# Altium schematic sheet P03_Processor 1 of 3, JTAG Header.SchDoc
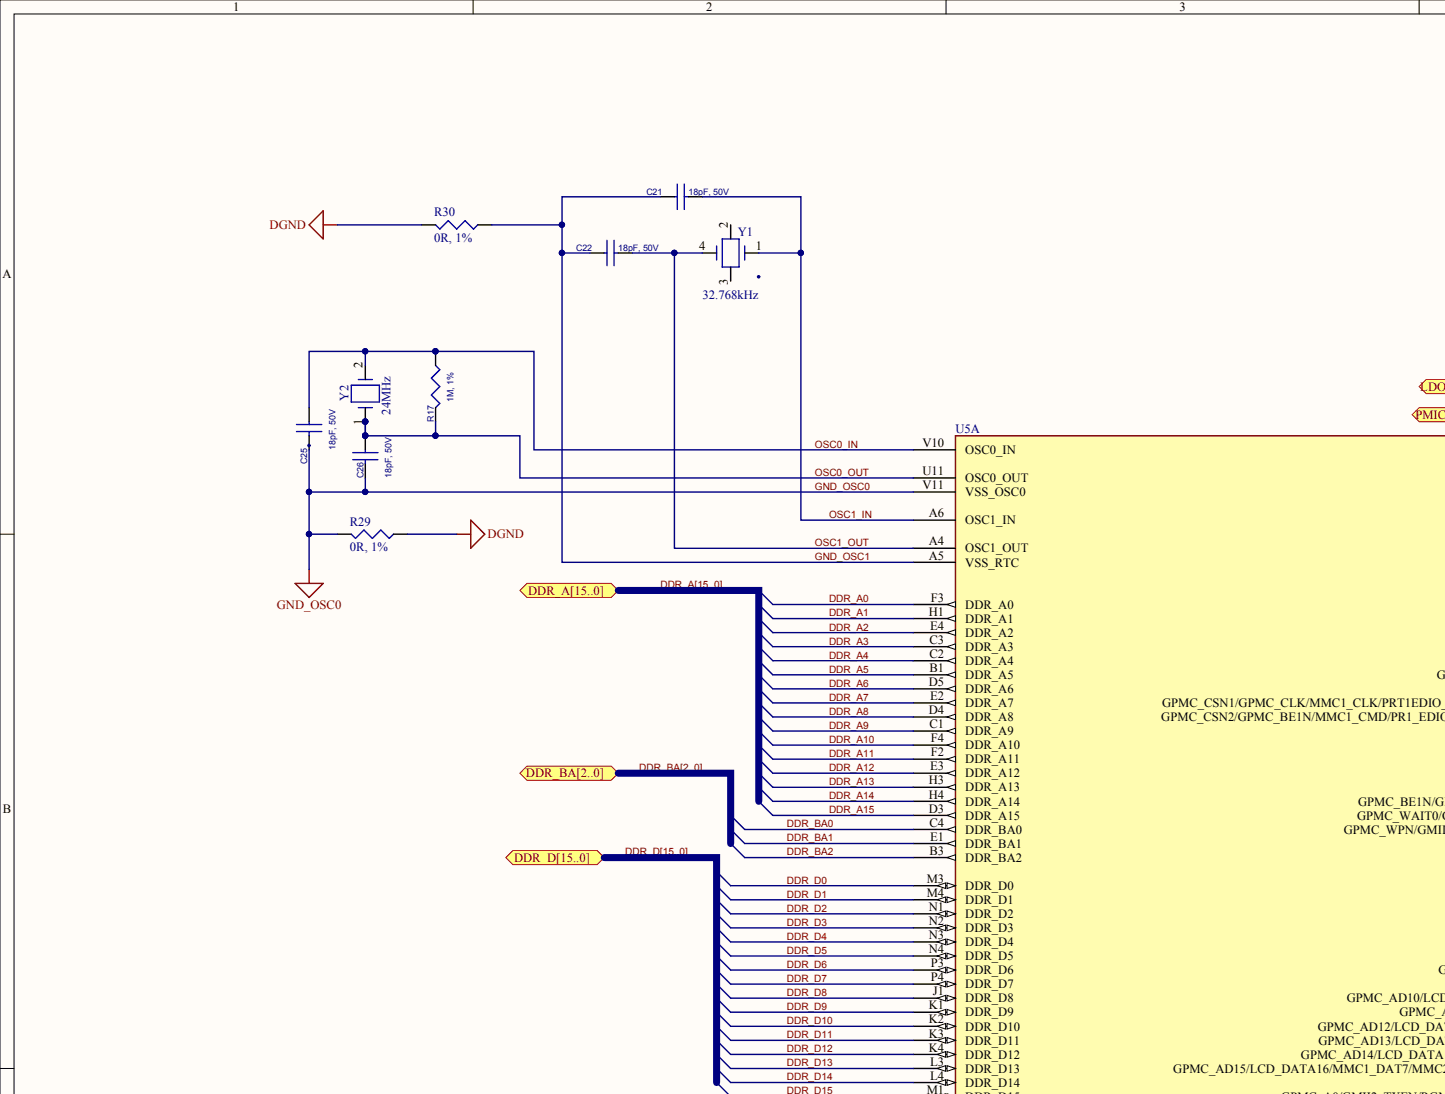
[diagram p0: P03_Processor 1 of 3, JTAG Header.SchDoc, top left]
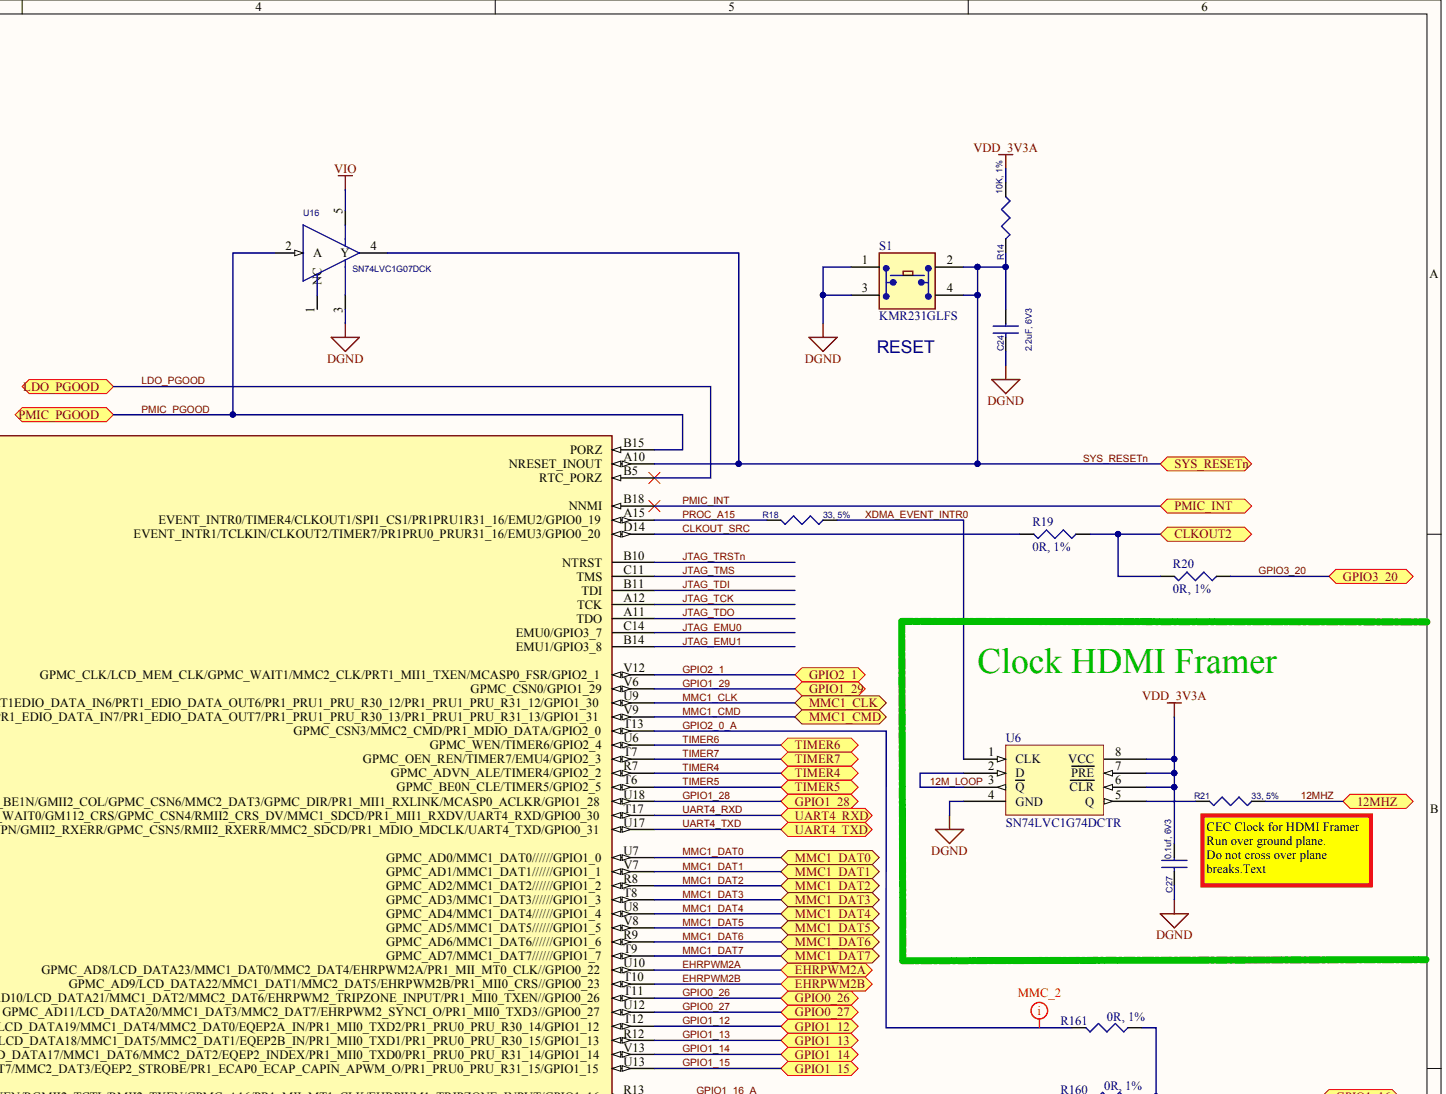
[diagram p1: P03_Processor 1 of 3, JTAG Header.SchDoc, top right]
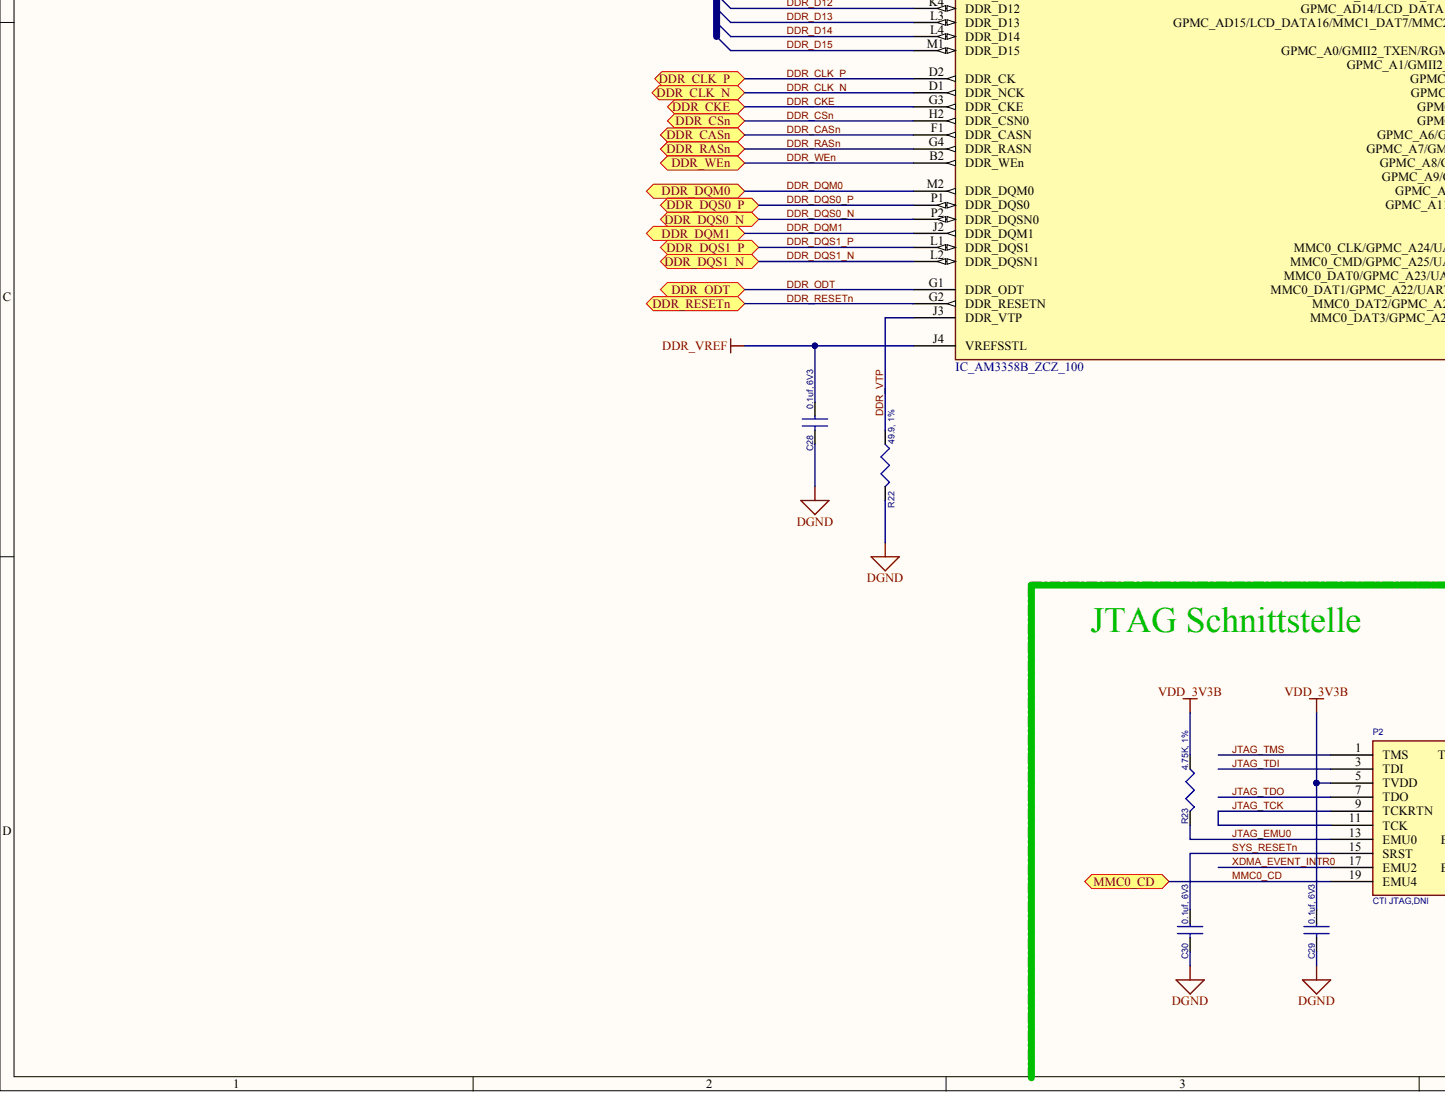
[diagram p2: P03_Processor 1 of 3, JTAG Header.SchDoc, bottom left]
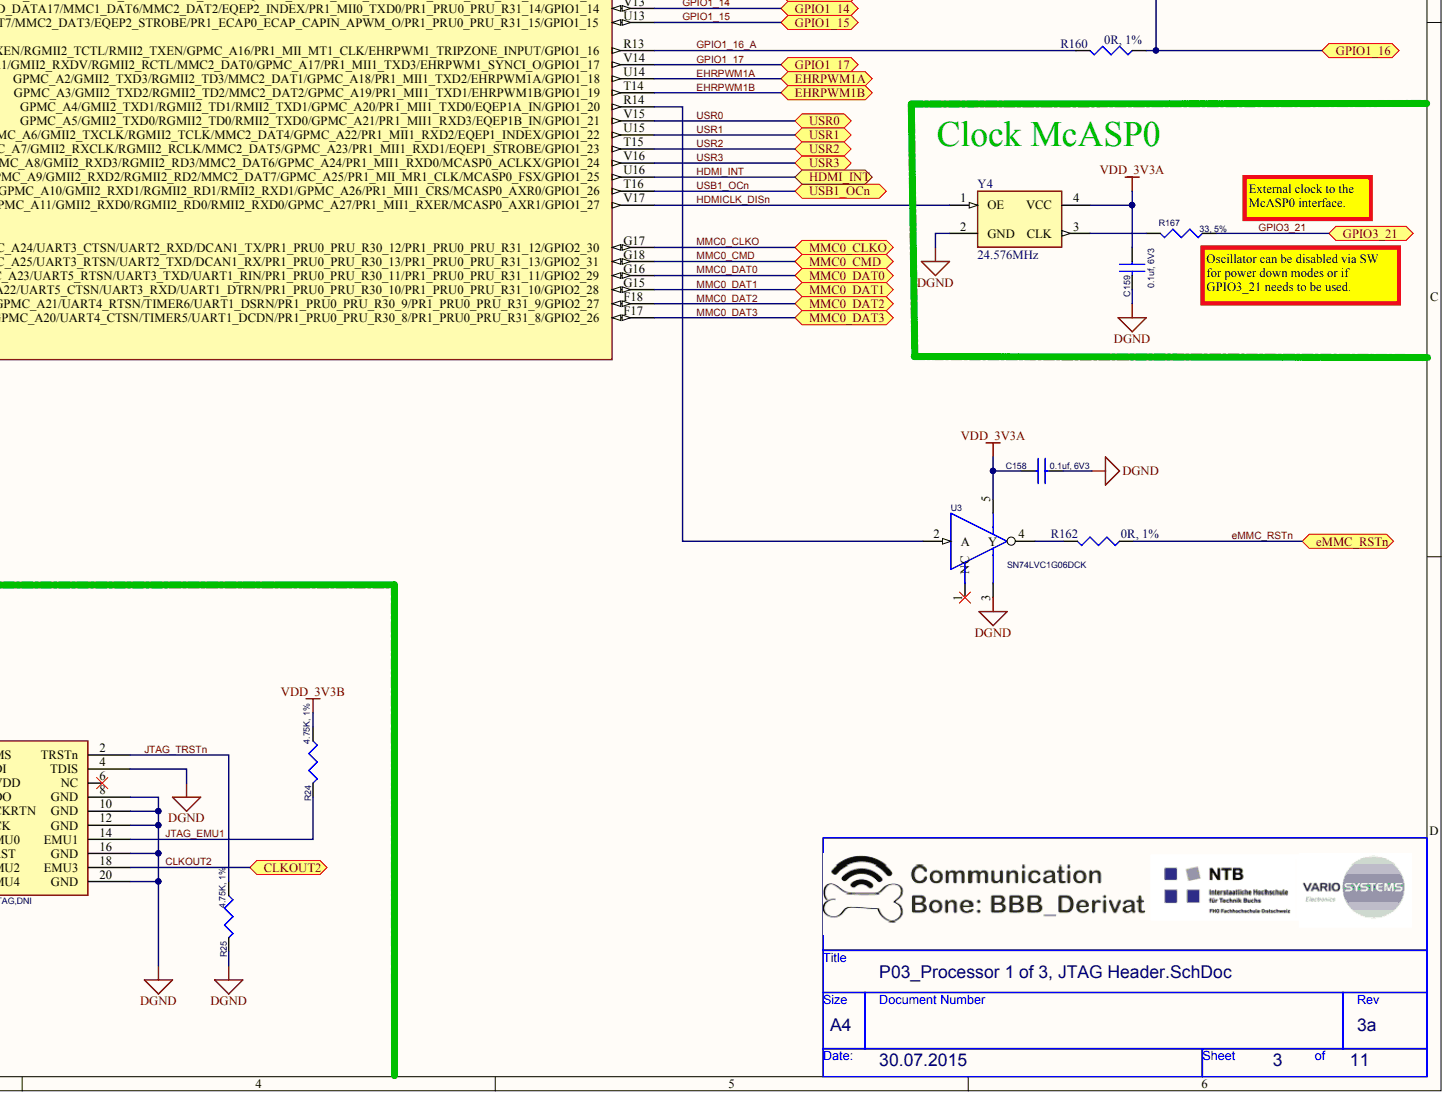
[diagram p3: P03_Processor 1 of 3, JTAG Header.SchDoc, bottom right]

## Parts
C21  18pF, 50V  Voltage - Rated: 50V  [402]
C22  18pF, 50V  Voltage - Rated: 50V  [402]
C24  2.2uF, 6V3  [402]
C25  18pF, 50V  Voltage - Rated: 50V  [402]
C26  18pF, 50V  Voltage - Rated: 50V  [402]
C27  0.1uf, 6V3  [402]
C28  0.1uf, 6V3  [402]
C29  0.1uf, 6V3  [402]
C30  0.1uf, 6V3  [402]
C158  0.1uf, 6V3  [402]
C159  0.1uf, 6V3  [402]
P2  CTI JTAG,DNI  [HEADER10X2_SMD]
R14  10K, 1%  [402]
R17  1M, 1%  [402]
R18  33, 5%  [402]
R19  0R, 1%  [402]
R20  0R, 1%  [402]
R21  33, 5%  [402]
R22  49.9, 1%  [402]
R23  4.75K, 1%  [402]
R24  4.75K, 1%  [402]
R25  4.75K, 1%  [402]
R29  0R, 1%  [402]
R30  0R, 1%  [402]
R160  0R, 1%  [402]
R161  0R, 1%  [402]
R162  0R, 1%  [402]
R167  33, 5%  [402]
S1  KMR231GLFS  [SW4SMD_63X161LS]
U3  SN74LVC1G06DCK  [DCK5_2P15X1P4]
U5  IC_AM3358B_ZCZ_100  [am33xx_15x15]  (part A)
U6  SN74LVC1G74DCTR  [SOP8_DCT]
U16  SN74LVC1G07DCK  [DCK5_2P15X1P4]
Y1  32.768kHz  [XTAL4_126-216SMD]
Y2  24MHz  [XTAL150SMD_125X196]
Y4  24.576MHz  [OSC4_61X65LS_79X98SMD]

## Ports
12MHZ, CLKOUT2, DDR_A0, DDR_A1, DDR_A2, DDR_A3, DDR_A4, DDR_A5, DDR_A6, DDR_A7, DDR_A8, DDR_A9, DDR_A10, DDR_A11, DDR_A12, DDR_A13, DDR_A14, DDR_A15, DDR_A[15..0], DDR_BA0, DDR_BA1, DDR_BA2, DDR_BA[2..0], DDR_CASN, DDR_CKE, DDR_CLK_N, DDR_CLK_P, DDR_CSN, DDR_D0, DDR_D1, DDR_D2, DDR_D3, DDR_D4, DDR_D5, DDR_D6, DDR_D7, DDR_D8, DDR_D9, DDR_D10, DDR_D11, DDR_D12, DDR_D13, DDR_D14, DDR_D15, DDR_D[15..0], DDR_DQM0, DDR_DQM1, DDR_DQS0_N, DDR_DQS0_P, DDR_DQS1_N, DDR_DQS1_P, DDR_ODT, DDR_RASN, DDR_RESETN, DDR_WEN, EHRPWM1A, EHRPWM1B, EHRPWM2A, EHRPWM2B, EMMC_RSTN, GPIO0_26, GPIO0_27, GPIO1_12, GPIO1_13, GPIO1_14, GPIO1_15, GPIO1_16, GPIO1_17, GPIO1_28, GPIO1_29, GPIO2_1, GPIO3_20, GPIO3_21, HDMI_INT, LDO_PGOOD, MMC0_CD, MMC0_CLKO, MMC0_CMD, MMC0_DAT0, MMC0_DAT1, MMC0_DAT2, MMC0_DAT3, MMC1_CLK, MMC1_CMD, MMC1_DAT0, MMC1_DAT1, MMC1_DAT2, MMC1_DAT3, MMC1_DAT4, MMC1_DAT5, MMC1_DAT6, MMC1_DAT7, PMIC_INT, PMIC_PGOOD, SYS_RESETN, TIMER4, TIMER5, TIMER6, TIMER7, UART4_RXD, UART4_TXD, USB1_OCN, USR0, USR1, USR2, USR3

## Nets
DDR_VREF: C28.1 U5.J4(VREFSSTL)
DGND: C24.2 C27.2 C28.2 C29.2 C30.2 C158.2 C159.2 P2.4(TDIS) P2.8(GND) P2.10(GND) P2.12(GND) P2.16(GND) P2.20(GND) R22.1 R25.2 R29.2 R30.1 S1.1 S1.3 U3.3 U6.4(GND) U16.3 Y4.2(GND)
VDD_3V3A: C27.1 C158.1 C159.1 R14.1 U3.5 U6.6(CLR) U6.7(PRE) U6.8(VCC) Y4.4(VCC)
VDD_3V3B: C29.1 P2.5(TVDD) R23.1 R24.1
VIO: U16.5
12M_LOOP: U6.2(D) U6.3(Q)
12MHZ: R21.2 ; port 12MHZ
CLKOUT2: P2.18(EMU3) R19.2 R20.1 ; port CLKOUT2
CLKOUT_SRC: R19.1 U5.D14(EVENT_INTR1/TCLKIN/CLKOUT2/TIMER7/PR1PRU0_PRUR31_16/EMU3/GPIO0_20)
DDR_A0: U5.F3(DDR_A0) ; port DDR_A[15..0]  [also labelled DDR_A]
DDR_A1: U5.H1(DDR_A1) ; port DDR_A[15..0]  [also labelled DDR_A]
DDR_A2: U5.E4(DDR_A2) ; port DDR_A[15..0]  [also labelled DDR_A]
DDR_A3: U5.C3(DDR_A3) ; port DDR_A[15..0]  [also labelled DDR_A]
DDR_A4: U5.C2(DDR_A4) ; port DDR_A[15..0]  [also labelled DDR_A]
DDR_A5: U5.B1(DDR_A5) ; port DDR_A[15..0]  [also labelled DDR_A]
DDR_A6: U5.D5(DDR_A6) ; port DDR_A[15..0]  [also labelled DDR_A]
DDR_A7: U5.E2(DDR_A7) ; port DDR_A[15..0]  [also labelled DDR_A]
DDR_A8: U5.D4(DDR_A8) ; port DDR_A[15..0]  [also labelled DDR_A]
DDR_A9: U5.C1(DDR_A9) ; port DDR_A[15..0]  [also labelled DDR_A]
DDR_A10: U5.F4(DDR_A10) ; port DDR_A[15..0]  [also labelled DDR_A]
DDR_A11: U5.F2(DDR_A11) ; port DDR_A[15..0]  [also labelled DDR_A]
DDR_A12: U5.E3(DDR_A12) ; port DDR_A[15..0]  [also labelled DDR_A]
DDR_A13: U5.H3(DDR_A13) ; port DDR_A[15..0]  [also labelled DDR_A]
DDR_A14: U5.H4(DDR_A14) ; port DDR_A[15..0]  [also labelled DDR_A]
DDR_A15: U5.D3(DDR_A15) ; port DDR_A[15..0]  [also labelled DDR_A]
DDR_BA0: U5.C4(DDR_BA0) ; port DDR_BA[2..0]  [also labelled DDR_BA]
DDR_BA1: U5.E1(DDR_BA1) ; port DDR_BA[2..0]  [also labelled DDR_BA]
DDR_BA2: U5.B3(DDR_BA2) ; port DDR_BA[2..0]  [also labelled DDR_BA]
DDR_CASN: U5.F1(DDR_CASN) ; port DDR_CASN
DDR_CKE: U5.G3(DDR_CKE) ; port DDR_CKE
DDR_CLK_N: U5.D1(DDR_NCK) ; port DDR_CLK_N
DDR_CLK_P: U5.D2(DDR_CK) ; port DDR_CLK_P
DDR_CSN: U5.H2(DDR_CSN0) ; port DDR_CSN
DDR_D0: U5.M3(DDR_D0) ; port DDR_D[15..0]  [also labelled DDR_D]
DDR_D1: U5.M4(DDR_D1) ; port DDR_D[15..0]  [also labelled DDR_D]
DDR_D2: U5.N1(DDR_D2) ; port DDR_D[15..0]  [also labelled DDR_D]
DDR_D3: U5.N2(DDR_D3) ; port DDR_D[15..0]  [also labelled DDR_D]
DDR_D4: U5.N3(DDR_D4) ; port DDR_D[15..0]  [also labelled DDR_D]
DDR_D5: U5.N4(DDR_D5) ; port DDR_D[15..0]  [also labelled DDR_D]
DDR_D6: U5.P3(DDR_D6) ; port DDR_D[15..0]  [also labelled DDR_D]
DDR_D7: U5.P4(DDR_D7) ; port DDR_D[15..0]  [also labelled DDR_D]
DDR_D8: U5.J1(DDR_D8) ; port DDR_D[15..0]  [also labelled DDR_D]
DDR_D9: U5.K1(DDR_D9) ; port DDR_D[15..0]  [also labelled DDR_D]
DDR_D10: U5.K2(DDR_D10) ; port DDR_D[15..0]  [also labelled DDR_D]
DDR_D11: U5.K3(DDR_D11) ; port DDR_D[15..0]  [also labelled DDR_D]
DDR_D12: U5.K4(DDR_D12) ; port DDR_D[15..0]  [also labelled DDR_D]
DDR_D13: U5.L3(DDR_D13) ; port DDR_D[15..0]  [also labelled DDR_D]
DDR_D14: U5.L4(DDR_D14) ; port DDR_D[15..0]  [also labelled DDR_D]
DDR_D15: U5.M1(DDR_D15) ; port DDR_D[15..0]  [also labelled DDR_D]
DDR_DQM0: U5.M2(DDR_DQM0) ; port DDR_DQM0
DDR_DQM1: U5.J2(DDR_DQM1) ; port DDR_DQM1
DDR_DQS0_N: U5.P2(DDR_DQSN0) ; port DDR_DQS0_N
DDR_DQS0_P: U5.P1(DDR_DQS0) ; port DDR_DQS0_P
DDR_DQS1_N: U5.L2(DDR_DQSN1) ; port DDR_DQS1_N
DDR_DQS1_P: U5.L1(DDR_DQS1) ; port DDR_DQS1_P
DDR_ODT: U5.G1(DDR_ODT) ; port DDR_ODT
DDR_RASN: U5.G4(DDR_RASN) ; port DDR_RASN
DDR_RESETN: U5.G2(DDR_RESETN) ; port DDR_RESETN
DDR_VTP: R22.2 U5.J3(DDR_VTP)
DDR_WEN: U5.B2(DDR_WEn) ; port DDR_WEN
EHRPWM1A: U5.U14(GPMC_A2/GMII2_TXD3/RGMII2_TD3/MMC2_DAT1/GPMC_A18/PR1_MII1_TXD2/EHRPWM1A/GPIO1_18) ; port EHRPWM1A
EHRPWM1B: U5.T14(GPMC_A3/GMII2_TXD2/RGMII2_TD2/MMC2_DAT2/GPMC_A19/PR1_MII1_TXD1/EHRPWM1B/GPIO1_19) ; port EHRPWM1B
EHRPWM2A: U5.U10(GPMC_AD8/LCD_DATA23/MMC1_DAT0/MMC2_DAT4/EHRPWM2A/PR1_MII_MT0_CLK//GPIO0_22) ; port EHRPWM2A
EHRPWM2B: U5.T10(GPMC_AD9/LCD_DATA22/MMC1_DAT1/MMC2_DAT5/EHRPWM2B/PR1_MII0_CRS//GPIO0_23) ; port EHRPWM2B
EMMC_RSTN: R162.2 ; port EMMC_RSTN
GND_OSC0: C25.2 C26.2 R29.1 U5.V11(VSS_OSC0)
GND_OSC1: C21.1 C22.1 R30.2 U5.A5(VSS_RTC)
GPIO0_26: U5.T11(GPMC_AD10/LCD_DATA21/MMC1_DAT2/MMC2_DAT6/EHRPWM2_TRIPZONE_INPUT/PR1_MII0_TXEN//GPIO0_26) ; port GPIO0_26
GPIO0_27: U5.U12(GPMC_AD11/LCD_DATA20/MMC1_DAT3/MMC2_DAT7/EHRPWM2_SYNCI_O/PR1_MII0_TXD3//GPIO0_27) ; port GPIO0_27
GPIO1_12: U5.T12(GPMC_AD12/LCD_DATA19/MMC1_DAT4/MMC2_DAT0/EQEP2A_IN/PR1_MII0_TXD2/PR1_PRU0_PRU_R30_14/GPIO1_12) ; port GPIO1_12
GPIO1_13: U5.R12(GPMC_AD13/LCD_DATA18/MMC1_DAT5/MMC2_DAT1/EQEP2B_IN/PR1_MII0_TXD1/PR1_PRU0_PRU_R30_15/GPIO1_13) ; port GPIO1_13
GPIO1_14: U5.V13(GPMC_AD14/LCD_DATA17/MMC1_DAT6/MMC2_DAT2/EQEP2_INDEX/PR1_MII0_TXD0/PR1_PRU0_PRU_R31_14/GPIO1_14) ; port GPIO1_14
GPIO1_15: U5.U13(GPMC_AD15/LCD_DATA16/MMC1_DAT7/MMC2_DAT3/EQEP2_STROBE/PR1_ECAP0_ECAP_CAPIN_APWM_O/PR1_PRU0_PRU_R31_15/GPIO1_15) ; port GPIO1_15
GPIO1_16: R160.2 R161.2 ; port GPIO1_16
GPIO1_16_A: R160.1 U5.R13(GPMC_A0/GMII2_TXEN/RGMII2_TCTL/RMII2_TXEN/GPMC_A16/PR1_MII_MT1_CLK/EHRPWM1_TRIPZONE_INPUT/GPIO1_16)
GPIO1_17: U5.V14(GPMC_A1/GMII2_RXDV/RGMII2_RCTL/MMC2_DAT0/GPMC_A17/PR1_MII1_TXD3/EHRPWM1_SYNCI_O/GPIO1_17) ; port GPIO1_17
GPIO1_28: U5.U18(GPMC_BE1N/GMII2_COL/GPMC_CSN6/MMC2_DAT3/GPMC_DIR/PR1_MII1_RXLINK/MCASP0_ACLKR/GPIO1_28) ; port GPIO1_28
GPIO1_29: U5.V6(GPMC_CSN0/GPIO1_29) ; port GPIO1_29
GPIO2_0_A: R161.1 U5.T13(GPMC_CSN3/MMC2_CMD/PR1_MDIO_DATA/GPIO2_0)
GPIO2_1: U5.V12(GPMC_CLK/LCD_MEM_CLK/GPMC_WAIT1/MMC2_CLK/PRT1_MII1_TXEN/MCASP0_FSR/GPIO2_1) ; port GPIO2_1
GPIO3_20: R20.2 ; port GPIO3_20
GPIO3_21: R167.2 ; port GPIO3_21
HDMI_INT: U5.U16(GPMC_A9/GMII2_RXD2/RGMII2_RD2/MMC2_DAT7/GPMC_A25/PR1_MII_MR1_CLK/MCASP0_FSX/GPIO1_25) ; port HDMI_INT
HDMICLK_DISN: U5.V17(GPMC_A11/GMII2_RXD0/RGMII2_RD0/RMII2_RXD0/GPMC_A27/PR1_MII1_RXER/MCASP0_AXR1/GPIO1_27) Y4.1(OE)
JTAG_EMU0: P2.13(EMU0) R23.2 U5.C14(EMU0/GPIO3_7)
JTAG_EMU1: P2.14(EMU1) R24.2 U5.B14(EMU1/GPIO3_8)
JTAG_TCK: P2.9(TCKRTN) P2.11(TCK) U5.A12(TCK)
JTAG_TDI: P2.3(TDI) U5.B11(TDI)
JTAG_TDO: P2.7(TDO) U5.A11(TDO)
JTAG_TMS: P2.1(TMS) U5.C11(TMS)
JTAG_TRSTN: P2.2(TRSTn) R25.1 U5.B10(NTRST)
LDO_PGOOD: U5.B5(RTC_PORZ) ; port LDO_PGOOD
MMC0_CD: P2.19(EMU4) ; port MMC0_CD
MMC0_CLKO: U5.G17(MMC0_CLK/GPMC_A24/UART3_CTSN/UART2_RXD/DCAN1_TX/PR1_PRU0_PRU_R30_12/PR1_PRU0_PRU_R31_12/GPIO2_30) ; port MMC0_CLKO
MMC0_CMD: U5.G18(MMC0_CMD/GPMC_A25/UART3_RTSN/UART2_TXD/DCAN1_RX/PR1_PRU0_PRU_R30_13/PR1_PRU0_PRU_R31_13/GPIO2_31) ; port MMC0_CMD
MMC0_DAT0: U5.G16(MMC0_DAT0/GPMC_A23/UART5_RTSN/UART3_TXD/UART1_RIN/PR1_PRU0_PRU_R30_11/PR1_PRU0_PRU_R31_11/GPIO2_29) ; port MMC0_DAT0
MMC0_DAT1: U5.G15(MMC0_DAT1/GPMC_A22/UART5_CTSN/UART3_RXD/UART1_DTRN/PR1_PRU0_PRU_R30_10/PR1_PRU0_PRU_R31_10/GPIO2_28) ; port MMC0_DAT1
MMC0_DAT2: U5.F18(MMC0_DAT2/GPMC_A21/UART4_RTSN/TIMER6/UART1_DSRN/PR1_PRU0_PRU_R30_9/PR1_PRU0_PRU_R31_9/GPIO2_27) ; port MMC0_DAT2
MMC0_DAT3: U5.F17(MMC0_DAT3/GPMC_A20/UART4_CTSN/TIMER5/UART1_DCDN/PR1_PRU0_PRU_R30_8/PR1_PRU0_PRU_R31_8/GPIO2_26) ; port MMC0_DAT3
MMC1_CLK: U5.U9(GPMC_CSN1/GPMC_CLK/MMC1_CLK/PRT1EDIO_DATA_IN6/PRT1_EDIO_DATA_OUT6/PR1_PRU1_PRU_R30_12/PR1_PRU1_PRU_R31_12/GPIO1_30) ; port MMC1_CLK
MMC1_CMD: U5.V9(GPMC_CSN2/GPMC_BE1N/MMC1_CMD/PR1_EDIO_DATA_IN7/PR1_EDIO_DATA_OUT7/PR1_PRU1_PRU_R30_13/PR1_PRU1_PRU_R31_13/GPIO1_31) ; port MMC1_CMD
MMC1_DAT0: U5.U7(GPMC_AD0/MMC1_DAT0//////GPIO1_0) ; port MMC1_DAT0
MMC1_DAT1: U5.V7(GPMC_AD1/MMC1_DAT1//////GPIO1_1) ; port MMC1_DAT1
MMC1_DAT2: U5.R8(GPMC_AD2/MMC1_DAT2//////GPIO1_2) ; port MMC1_DAT2
MMC1_DAT3: U5.T8(GPMC_AD3/MMC1_DAT3//////GPIO1_3) ; port MMC1_DAT3
MMC1_DAT4: U5.U8(GPMC_AD4/MMC1_DAT4//////GPIO1_4) ; port MMC1_DAT4
MMC1_DAT5: U5.V8(GPMC_AD5/MMC1_DAT5//////GPIO1_5) ; port MMC1_DAT5
MMC1_DAT6: U5.R9(GPMC_AD6/MMC1_DAT6//////GPIO1_6) ; port MMC1_DAT6
MMC1_DAT7: U5.T9(GPMC_AD7/MMC1_DAT7//////GPIO1_7) ; port MMC1_DAT7
OSC0_IN: C25.1 R17.1 U5.V10(OSC0_IN) Y2.2
OSC0_OUT: C26.1 R17.2 U5.U11(OSC0_OUT) Y2.1
OSC1_IN: C21.2 U5.A6(OSC1_IN) Y1.1
OSC1_OUT: C22.2 U5.A4(OSC1_OUT) Y1.4
PMIC_INT: U5.B18(NNMI) ; port PMIC_INT
PMIC_PGOOD: U5.B15(PORZ) U16.2(A) ; port PMIC_PGOOD
PROC_A15: R18.1 U5.A15(EVENT_INTR0/TIMER4/CLKOUT1/SPI1_CS1/PR1PRU1R31_16/EMU2/GPIO0_19)
SYS_RESETN: C24.1 C30.1 P2.15(SRST) R14.2 S1.2 S1.4 U5.A10(NRESET_INOUT) U16.4(Y) ; port SYS_RESETN
TIMER4: U5.R7(GPMC_ADVN_ALE/TIMER4/GPIO2_2) ; port TIMER4
TIMER5: U5.T6(GPMC_BE0N_CLE/TIMER5/GPIO2_5) ; port TIMER5
TIMER6: U5.U6(GPMC_WEN/TIMER6/GPIO2_4) ; port TIMER6
TIMER7: U5.T7(GPMC_OEN_REN/TIMER7/EMU4/GPIO2_3) ; port TIMER7
UART4_RXD: U5.T17(GPMC_WAIT0/GM112_CRS/GPMC_CSN4/RMII2_CRS_DV/MMC1_SDCD/PR1_MII1_RXDV/UART4_RXD/GPIO0_30) ; port UART4_RXD
UART4_TXD: U5.U17(GPMC_WPN/GMII2_RXERR/GPMC_CSN5/RMII2_RXERR/MMC2_SDCD/PR1_MDIO_MDCLK/UART4_TXD/GPIO0_31) ; port UART4_TXD
USB1_OCN: U5.T16(GPMC_A10/GMII2_RXD1/RGMII2_RD1/RMII2_RXD1/GPMC_A26/PR1_MII1_CRS/MCASP0_AXR0/GPIO1_26) ; port USB1_OCN
USR0: U5.V15(GPMC_A5/GMII2_TXD0/RGMII2_TD0/RMII2_TXD0/GPMC_A21/PR1_MII1_RXD3/EQEP1B_IN/GPIO1_21) ; port USR0
USR1: U5.U15(GPMC_A6/GMII2_TXCLK/RGMII2_TCLK/MMC2_DAT4/GPMC_A22/PR1_MII1_RXD2/EQEP1_INDEX/GPIO1_22) ; port USR1
USR2: U5.T15(GPMC_A7/GMII2_RXCLK/RGMII2_RCLK/MMC2_DAT5/GPMC_A23/PR1_MII1_RXD1/EQEP1_STROBE/GPIO1_23) ; port USR2
USR3: U5.V16(GPMC_A8/GMII2_RXD3/RGMII2_RD3/MMC2_DAT6/GPMC_A24/PR1_MII1_RXD0/MCASP0_ACLKX/GPIO1_24) ; port USR3
XDMA_EVENT_INTR0: P2.17(EMU2) R18.2 U6.1(CLK)
NetR21_1: R21.1 U6.5(Q)
NetR162_1: R162.1 U3.4(Y)
NetR167_1: R167.1 Y4.3(CLK)
NetU3_2: U3.2(A) U5.R14(GPMC_A4/GMII2_TXD1/RGMII2_TD1/RMII2_TXD1/GPMC_A20/PR1_MII1_TXD0/EQEP1A_IN/GPIO1_20)

Not connected: P2 6(NC); U3 1(NC); U16 1(NC); Y1 2 3

## Library data of the parts
C21 C22 C25 C26 = CAP_18PF_COG_50V_5%_0402: CAP CER 18PF 50V 5% NP0 0402; Manufacturer: TDK Corporation; Manufacturer Part Number: C1005C0G1H180J050BA; VS Part Number: 256035; Temperature Coefficient: C0G, NP0; Applications: General Purpose; Capacitance: 18pF; Category: Capacitors; Component Kind: Standard; ComponentLink1Description: http://product.tdk.com/capacitor/mlcc/en/documents/mlcc_commercial_general_en.pdf; ComponentLink1URL: http://product.tdk.com/capacitor/mlcc/en/documents/mlcc_commercial_general_en.pdf; Features: -; Height - Seated (Max): -; Lead Spacing: -; Lead Style: -; Mounting Type: Surface Mount, MLCC; Operating Temperature: -55°C ~ 125°C; Package / Case: 0402 (1005 Metric); Packaging: Digi-Reel®; Pricing 1: 1=0.1, 10=0.024, 100=0.011, 250=0.009, 500=0.0077, 1000=0.006, 5000=0.005 (USD); Ratings: -; RoHS: RoHS Compliant; Size / Dimension: 0.039" L x 0.020" W (1.00mm x 0.50mm); Stock 1: 352554; Supplier 1: Digi-Key; Supplier Part Number 1: 445-1238-6-ND; Thickness (Max): 0.022" (0.55mm); Tolerance: ±5%; Library Name: BBB_Derivat.SchLib
C24 = CAP_2.2uF_X5R_6.3V_10%_0402: CAP CER 2.2UF 6.3V 10% X5R 0402; Manufacturer: TDK Corporation; Manufacturer Part Number: C1005X5R0J225K050BC; Capacitance: 2.2µF; Applications: General Purpose; Category: Capacitors; Component Kind: Standard; ComponentLink1Description: http://product.tdk.com/capacitor/mlcc/en/documents/mlcc_commercial_general_en.pdf; ComponentLink1URL: http://product.tdk.com/capacitor/mlcc/en/documents/mlcc_commercial_general_en.pdf; Features: -; Height - Seated (Max): -; Lead Spacing: -; Lead Style: -; Mounting Type: Surface Mount, MLCC; Operating Temperature: -55°C ~ 85°C; Package / Case: 0402 (1005 Metric); Packaging: Digi-Reel®; Pricing 1: 1=0.24, 10=0.2, 100=0.1, 250=0.075, 500=0.06626, 1000=0.05875, 5000=0.04875 (USD); Ratings: -; RoHS: RoHS Compliant; Size / Dimension: 0.039" L x 0.020" W (1.00mm x 0.50mm); Stock 1: 47221; Supplier 1: Digi-Key; Supplier Part Number 1: 445-6847-6-ND; Temperature Coefficient: X5R; Thickness (Max): 0.022" (0.55mm); Tolerance: ±10%; Voltage - Rated: 6.3V; Library Name: BBB_Derivat.SchLib
C27-C30 C158 C159 = CAP_0.1uF_X7R_16V_10% 0402: CAP CER 0.1UF 6.3V 10% X5R 0402; Manufacturer: TDK Corporation; Manufacturer Part Number: C1005X5R0J104K050BA; VS Part Number: 225065; Capacitance: 0.1µF; Applications: General Purpose; Category: Capacitors; Component Kind: Standard; ComponentLink1Description: http://www.kemet.com/datasheets&C0402C104K4RACTU; ComponentLink1URL: http://www.kemet.com/datasheets&C0402C104K4RACTU; Features: -; Height - Seated (Max): -; Lead Spacing: -; Lead Style: -; Mounting Type: Surface Mount, MLCC; Operating Temperature: -55°C ~ 85°C; Package / Case: 0402 (1005 Metric); Packaging: Digi-Reel®; Pricing 1: 1=0.1, 10=0.026, 100=0.0121, 250=0.00992, 500=0.00848, 1000=0.0066, 5000=0.0055 (USD); Ratings: -; RoHS: RoHS Compliant; Size / Dimension: 0.039" L x 0.020" W (1.00mm x 0.50mm); Stock 1: 127604; Supplier 1: Digi-Key; Supplier Part Number 1: 445-1266-6-ND; Temperature Coefficient: X5R; Thickness (Max): 0.022" (0.55mm); Tolerance: ±10%; Voltage - Rated: 6.3V; Library Name: BBB_Derivat.SchLib
P2 = HDR_10x2_CJTAG: Dual Line Header 10x2pin Tin; Manufacturer: Samtec Inc; Manufacturer Part Number: FTR-110-03-G-D-06; Connector Type: Unshrouded; Molding Height Above Board: 0.100" (2.54mm); Number of Positions: 20; Number of Positions Loaded: 19; Pitch: 0.050" (1.27mm); Category: Connectors, Interconnects; Color: Black; Component Kind: Standard; ComponentLink1Description: http://www.samtec.com/documents/webfiles/cpdf/FTR-1XX-XX-XX-D-X-XX-MKT.pdf; ComponentLink1URL: http://www.samtec.com/documents/webfiles/cpdf/FTR-1XX-XX-XX-D-X-XX-MKT.pdf; Contact Finish: Gold; Contact Finish Thickness: 10µin (0.25µm); Contact Mating Length: 0.125" (3.18mm); Features: -; Height Stacking (Mating): -; Mounting Type: Surface Mount; Number of Rows: 2; Packaging: Tube; Pricing 1: 1=4.47 (USD); RoHS: RoHS Compliant; Row Spacing: 0.100" (2.54mm); Stock 1: 0; Supplier 1: Digi-Key; Supplier Part Number 1: FTR-110-03-G-D-06-ND; Termination: Solder; Library Name: BBB_Derivat.SchLib
R14 = RES_10K_1/16W_1%_0402: RES 10K OHM 1/16W 5% 0402 SMD; Manufacturer: Rohm Semiconductor; Manufacturer Part Number: MCR01MZPJ103; VS Part Number: 226218; Power (Watts): 0.063W, 1/16W; Temperature Coefficient: ±200ppm/°C; Category: Resistors; Component Kind: Standard; ComponentLink1Description: http://rohmfs.rohm.com/en/products/databook/datasheet/passive/resistor/chip_resistor/mcr_a.pdf; ComponentLink1URL: http://rohmfs.rohm.com/en/products/databook/datasheet/passive/resistor/chip_resistor/mcr_a.pdf; Composition: Thick Film; Features: Automotive AEC-Q200 Qualified; Height: 0.016" (0.40mm); Number of Terminations: 2; Package / Case: 0402 (1005 Metric); Packaging: Digi-Reel®; Resistance (Ohms): 10k; RoHS: RoHS Compliant; Size / Dimension: 0.039" L x 0.020" W (1.00mm x 0.50mm); Supplier 1: Digi-Key; Supplier Device Package: 0402 (1005 Metric); Supplier Part Number 1: RHM10KCEDKR-ND; Tolerance: ±5%; Library Name: BBB_Derivat.SchLib
R17 = RES_1M_1/16W_1%_0402: Resistor 1Mohm 1/16W 1% 0402; Manufacturer: Stackpole Electronics Inc; Manufacturer Part Number: RMCF0402FT1M00; VS Part Number: 238344; Power (Watts): 0.063W, 1/16W; Temperature Coefficient: ±100ppm/°C; Category: Resistors; Component Kind: Standard; ComponentLink1Description: http://www.seielect.com/Catalog/SEI-RMCF_RMCP.pdf; ComponentLink1URL: http://www.seielect.com/Catalog/SEI-RMCF_RMCP.pdf; Composition: Thick Film; Features: -; Height: 0.016" (0.40mm); Number of Terminations: 2; Package / Case: 0402 (1005 Metric); Packaging: Digi-Reel®; Pricing 1: 1=0.04, 10=0.025, 50=0.0138, 100=0.0105, 250=0.0078, 500=0.00576, 1000=0.00384, 2500=0.00324, 5000=0.0027 (USD); Resistance (Ohms): 1M; RoHS: RoHS Compliant; Size / Dimension: 0.039" L x 0.020" W (1.00mm x 0.50mm); Stock 1: 175650; Supplier 1: Digi-Key; Supplier Device Package: 0402; Supplier Part Number 1: RMCF0402FT1M00DKR-ND; Tolerance: ±1%; Library Name: BBB_Derivat.SchLib
R18 R21 R167 = RES_33_1/16W_5%_0402: RES 33 OHM 1/16W 5% 0402 SMD; Manufacturer: Panasonic Electronic Components; Manufacturer Part Number: ERJ-2GEJ330X; VS Part Number: 254131; Power (Watts): 0.1W, 1/10W; Resistance (Ohms): 33; Temperature Coefficient: ±200ppm/°C; Category: Resistors; Component Kind: Standard; ComponentLink1Description: http://industrial.panasonic.com/www-cgi/jvcr13pz.cgi?E+PZ+3+AOA0001+ERJ2GEJ330X+7+WW; ComponentLink1URL: http://industrial.panasonic.com/www-cgi/jvcr13pz.cgi?E+PZ+3+AOA0001+ERJ2GEJ330X+7+WW; Composition: Thick Film; Features: -; Height: 0.016" (0.40mm); Number of Terminations: 2; Package / Case: 0402 (1005 Metric); Packaging: Digi-Reel®; Pricing 1: 1=0.1, 50=0.0172, 100=0.0131, 250=0.00976, 500=0.0072, 1000=0.0048, 5000=0.00338 (USD); RoHS: RoHS Compliant; Size / Dimension: 0.039" L x 0.020" W (1.00mm x 0.50mm); Stock 1: 5879945; Supplier 1: Digi-Key; Supplier Device Package: 0402; Supplier Part Number 1: P33JDKR-ND; Tolerance: ±5%; Library Name: BBB_Derivat.SchLib
R19 R20 R29 R30 R160-R162 = RES_0_1/16W_1%_0402: RES 0.0 OHM 1/16W 0402 SMD; Manufacturer: Yageo; Manufacturer Part Number: RC0402JR-070RL; VS Part Number: 226229; Power (Watts): 0.063W, 1/16W; Resistance (Ohms): 0.0; Tolerance: Jumper; Category: Resistors; Component Kind: Standard; ComponentLink1Description: http://www.yageo.com/documents/recent/PYu-RC0402_51_RoHS_L_6.pdf; ComponentLink1URL: http://www.yageo.com/documents/recent/PYu-RC0402_51_RoHS_L_6.pdf; Composition: Thick Film; Features: -; Height: 0.016" (0.40mm); Number of Terminations: 2; Package / Case: 0402 (1005 Metric); Packaging: Digi-Reel®; Pricing 1: 1=0.1, 10=0.012, 25=0.0092, 100=0.0055, 250=0.00476, 500=0.00336, 1000=0.00237, 2500=0.00206, 5000=0.00178 (USD); RoHS: RoHS Compliant; Size / Dimension: 0.039" L x 0.020" W (1.00mm x 0.50mm); Stock 1: 4904429; Supplier 1: Digi-Key; Supplier Device Package: 0402; Supplier Part Number 1: 311-0.0JRDKR-ND; Temperature Coefficient: -; Library Name: BBB_Derivat.SchLib
R22 = RES_49.9_1/16_1%_0402: RES 49.9 OHM 1/16W 1% 0402 SMD; Manufacturer: Yageo; Manufacturer Part Number: RC0402FR-0749R9L; Power (Watts): 0.063W, 1/16W; Resistance (Ohms): 49.9; Temperature Coefficient: ±100ppm/°C; Category: Resistors; Component Kind: Standard; ComponentLink1Description: http://www.yageo.com/documents/recent/PYu-RC0402_51_RoHS_L_6.pdf; ComponentLink1URL: http://www.yageo.com/documents/recent/PYu-RC0402_51_RoHS_L_6.pdf; Composition: Thick Film; Features: -; Height: 0.016" (0.40mm); Number of Terminations: 2; Package / Case: 0402 (1005 Metric); Packaging: Digi-Reel®; Pricing 1: 1=0.1, 10=0.014, 25=0.0104, 100=0.0063, 250=0.0054, 500=0.00382, 1000=0.0027, 2500=0.00234, 5000=0.00203 (USD); RoHS: RoHS Compliant; Size / Dimension: 0.039" L x 0.020" W (1.00mm x 0.50mm); Stock 1: 1338786; Supplier 1: Digi-Key; Supplier Device Package: 0402; Supplier Part Number 1: 311-49.9LRDKR-ND; Tolerance: ±1%; Library Name: BBB_Derivat.SchLib
R23-R25 = RES_4.7K_1/16W_1%_0402: RES 4.75K 1/16W 1% 0402 SMD; Manufacturer: Stackpole Electronics Inc; Manufacturer Part Number: RMCF0402FT4K75; Power (Watts): 0.063W, 1/16W; Temperature Coefficient: ±100ppm/°C; Category: Resistors; Component Kind: Standard; ComponentLink1Description: http://www.seielect.com/Catalog/SEI-RMCF_RMCP.pdf; ComponentLink1URL: http://www.seielect.com/Catalog/SEI-RMCF_RMCP.pdf; Composition: Thick Film; Features: -; Height: 0.016" (0.40mm); Number of Terminations: 2; Package / Case: 0402 (1005 Metric); Packaging: Digi-Reel®; Pricing 1: 1=0.04, 10=0.025, 50=0.0138, 100=0.0105, 250=0.0078, 500=0.00576, 1000=0.00384, 2500=0.00324, 5000=0.0027 (USD); Resistance (Ohms): 4.75k; RoHS: RoHS Compliant; Size / Dimension: 0.039" L x 0.020" W (1.00mm x 0.50mm); Stock 1: 280611; Supplier 1: Digi-Key; Supplier Device Package: 0402; Supplier Part Number 1: RMCF0402FT4K75DKR-ND; Tolerance: ±1%; Library Name: BBB_Derivat.SchLib
S1 = KMR2: Microminiature Tact Switch for SMT; Manufacturer: C&K Components; Actuator Height off PCB, from Pins: 1.90mm; Actuator Orientation: Top Actuated; Actuator Type: Standard; Circuit: SPST-NO; Contact Rating @ Voltage: 0.05A @ 32VDC; Illumination: Non-Illuminated; Mechanical Life: 150,000 Cycles; Operating Force: 300gf; Outline: 4.60mm x 2.80mm; Switch Function: Off-Mom; Switch Travel: 0.25mm; Actuator Material: -; Category: Switches; Component Kind: Standard; ComponentLink1Description: http://www.ck-components.com/index.php?module=media&action=Display&cmpref=14414&lang=en&width=&height=&format=&alt=; ComponentLink1URL: http://www.ck-components.com/index.php?module=media&action=Display&cmpref=14414&lang=en&width=&height=&format=&alt=; Features: Grounding Pin; Illumination Type, Color: -; Illumination Voltage (Nominal): -; Ingress Protection: -; Manufacturer Part Number: KMR231GLFS; Mounting Type: Surface Mount; Operating Temperature: -40°C ~ 85°C; Packaging: Digi-Reel®; Pricing 1: 1=0.44, 10=0.416, 25=0.3744, 50=0.3326, 100=0.3188, 250=0.29108, 500=0.2772, 1000=0.22869 (USD); RoHS: RoHS Compliant; Stock 1: 32289; Supplier 1: Digi-Key; Supplier Part Number 1: 401-1429-6-ND; Termination Style: Gull Wing; Library Name: BBB_Derivat.SchLib
U3 = SN74LVC1G06DCK: IC INVERTER SINGLE 1INPUT SC705; Manufacturer: Texas Instruments; Manufacturer Part Number: SN74LVC1G06DCKTG4; Current - Output High, Low: -, 32mA; Current - Quiescent (Max): 10µA; Logic Level - High: 1.7 V ~ 2 V; Logic Level - Low: 0.7 V ~ 0.8 V; Logic Type: Inverter, Buffer; Max Propagation Delay @ V, Max CL: 3ns @ 5V, 50pF; Number of Circuits: 1; Voltage - Supply: 1.65 V ~ 5.5 V; Category: Integrated Circuits (ICs); Component Kind: Standard; ComponentLink1Description: http://www.ti.com/lit/ds/symlink/sn74lvc1g06.pdf; ComponentLink1URL: http://www.ti.com/lit/ds/symlink/sn74lvc1g06.pdf; Features: Open Drain; Mounting Type: Surface Mount; Number of Inputs: 1; Operating Temperature: -40°C ~ 85°C; Package / Case: 6-TSSOP (5 Lead), SC-88A, SOT-353; Packaging: Tape & Reel (TR); RoHS: RoHS Compliant; Supplier 1: Digi-Key; Supplier Device Package: SC-70-5; Supplier Part Number 1: 296-8484-6-ND; Library Name: BBB_Derivat.SchLib
U5 = IC_AM3358B_ZCZ_100: SubArctic Cortex A8 Processor 15x15 1000MHz; Manufacturer: Texas Instruments; Manufacturer Part Number: AM3358ZCZ100; Processor Type: ARM Microprocessor; Voltage: 1.06 V ~ 1.15 V; Category: Integrated Circuits (ICs); Component Kind: Standard; ComponentLink1Description: http://www.ti.com/lit/ds/symlink/am3354.pdf; ComponentLink1URL: http://www.ti.com/lit/ds/symlink/am3354.pdf; Features: -; Mounting Type: Surface Mount; Package / Case: 324-LFBGA; Packaging: Tray; RoHS: RoHS Compliant; Speed: -; Supplier 1: Digi-Key; Supplier Device Package: 324-NFBGA(15x15); Supplier Part Number 1: 296-35869-5-ND; Library Name: BBB_Derivat.SchLib
U6 = SN74LVC1G74DCTR: SINGLE  POSITIVE-EDGE-TRIGGERED  D-TYPE  FLIP-FLOP WITH  CLEAR  AND  PRESET; Manufacturer: Texas Instruments; Current - Output High, Low: 32mA, 32mA; Delay Time - Propagation: 1.6ns; Frequency - Clock: 200MHz; Function: Set(Preset) and Reset; Number of Bits per Element: 1; Output Type: Differential; Trigger Type: Positive Edge; Voltage - Supply: 1.65 V ~ 5.5 V; Category: Integrated Circuits (ICs); Component Kind: Standard; ComponentLink1Description: http://media.digikey.com/pdf/Data%20Sheets/Texas%20Instruments%20PDFs/SN74LVC1G74.pdf; ComponentLink1URL: http://media.digikey.com/pdf/Data%20Sheets/Texas%20Instruments%20PDFs/SN74LVC1G74.pdf; Manufacturer Part Number: SN74LVC1G74DCTR; Mounting Type: Surface Mount; Number of Elements: 1; Operating Temperature: -40°C ~ 125°C; Package / Case: 8-LSSOP (0.11", 2.80mm Width); Packaging: Digi-Reel®; Pricing 1: 1=0.59, 10=0.494, 25=0.4332, 100=0.3708, 250=0.3216, 500=0.2724, 1000=0.21 (USD); RoHS: RoHS Compliant; Stock 1: 131; Supplier 1: Digi-Key; Supplier Part Number 1: 296-25553-6-ND; Type: D-Type; Library Name: BBB_Derivat.SchLib
U16 = SN74LVC1G07DCK: IC DRIVER/BUFFER SINGLE 1INPUT SC705; Manufacturer: Texas Instruments; Manufacturer Part Number: SN74LVC1G07DCKTG4; Current - Output High, Low: -, 32mA; Current - Quiescent (Max): 10µA; Logic Level - High: 1.7 V ~ 2 V; Logic Level - Low: 0.7 V ~ 0.8 V; Logic Type: Non-Inverted, Buffer; Max Propagation Delay @ V, Max CL: 3ns @ 5V, 50pF; Number of Circuits: 1; Voltage - Supply: 1.65 V ~ 5.5 V; Category: Integrated Circuits (ICs); Component Kind: Standard; ComponentLink1Description: http://www.ti.com/lit/ds/symlink/sn74lvc1g06.pdf; ComponentLink1URL: http://www.ti.com/lit/ds/symlink/sn74lvc1g06.pdf; Features: Open Drain; Mounting Type: Surface Mount; Number of Inputs: 1; Operating Temperature: -40°C ~ 85°C; Package / Case: 6-TSSOP (5 Lead), SC-88A, SOT-353; Packaging: Tape & Reel (TR); RoHS: RoHS Compliant; Supplier 1: Digi-Key; Supplier Device Package: SC-70-5; Supplier Part Number 1: 296-8486-6-ND; Library Name: BBB_Derivat.SchLib
Y1 = CRYS_32_768KHz_SMD32: CRYSTAL 32.768KHZ 12.5PF SMD; Manufacturer: Citizen Finetech Miyota; Manufacturer Part Number: CM200C-32.768KAZF-UT; ESR (Equivalent Series Resistance): 50 kOhm; Frequency Tolerance: ±30ppm; Operating Mode: Fundamental; Category: Crystals and Oscillators; Component Kind: Standard; ComponentLink1Description: http://cfm.citizen.co.jp/english/product/pdf/CM200C_250C.pdf; ComponentLink1URL: http://cfm.citizen.co.jp/english/product/pdf/CM200C_250C.pdf; Frequency: 32.768kHz; Frequency Stability: -; Height: 0.098" (2.50mm); Load Capacitance: 12.5pF; Mounting Type: Surface Mount; Operating Temperature: -40°C ~ 85°C; Package / Case: 4-SOJ, 5.50mm pitch; Packaging: Digi-Reel®; Pricing 1: 1=0.75, 10=0.65, 50=0.57, 100=0.45, 500=0.38, 1000=0.3 (USD); Ratings: -; RoHS: RoHS Compliant; Size / Dimension: 0.311" L x 0.146" W (7.90mm x 3.70mm); Stock 1: 0; Supplier 1: Digi-Key; Supplier Part Number 1: 300-8738-6-ND; Type: kHz Crystal (Tuning Fork); Library Name: BBB_Derivat.SchLib
Y2 = CRYS_24MHz_SCC: CRYSTAL 24.000MHZ 18PF SMD; Manufacturer: TXC CORPORATION; Manufacturer Part Number: 7A-24.000MAAJ-T; ESR (Equivalent Series Resistance): 50 Ohm; Frequency Stability: ±30ppm; Operating Mode: Fundamental; Category: Crystals and Oscillators; Component Kind: Standard; ComponentLink1Description: http://www.txccrystal.com/images/pdf/7a.pdf; ComponentLink1URL: http://www.txccrystal.com/images/pdf/7a.pdf; Frequency: 24MHz; Frequency Tolerance: ±30ppm; Height: 0.053" (1.35mm); Load Capacitance: 18pF; Mounting Type: Surface Mount; Operating Temperature: -20°C ~ 70°C; Package / Case: 2-SMD; Packaging: Digi-Reel®; Pricing 1: 1=0.79, 10=0.658, 50=0.59, 100=0.525, 500=0.5 (USD); Ratings: -; RoHS: RoHS Compliant; Size / Dimension: 0.197" L x 0.126" W (5.00mm x 3.20mm); Stock 1: 2524; Supplier 1: Digi-Key; Supplier Part Number 1: 887-1090-6-ND; Type: MHz Crystal; Library Name: BBB_Derivat.SchLib
Y4 = ASDMB: Manufacturer: Abracon Corporation; Manufacturer Part Number: ASDMB-24.576MHZ-LC-T; Current - Supply (Disable) (Max): 15µA; Current - Supply (Max): 16mA; Frequency Stability: ±50ppm; Function: Standby (Power Down); Output: CMOS; Voltage - Supply: 1.8 V ~ 3.3 V; Category: Crystals and Oscillators; Component Kind: Standard; ComponentLink1Description: http://www.abracon.com/Oscillators/ASDMB.pdf; ComponentLink1URL: http://www.abracon.com/Oscillators/ASDMB.pdf; Frequency: 24.576MHz; Height: 0.035" (0.90mm); Mounting Type: Surface Mount; Operating Temperature: -40°C ~ 85°C; Package / Case: 4-SMD, No Lead (DFN, LCC); Packaging: Digi-Reel®; Pricing 1: 1=1.69, 10=1.463, 50=1.2826, 100=1.0125, 500=0.855 (USD); Ratings: -; RoHS: RoHS Compliant; Size / Dimension: 0.098" L x 0.079" W (2.50mm x 2.00mm); Stock 1: 0; Supplier 1: Digi-Key; Supplier Part Number 1: 535-11729-6-ND; Type: MEMS (Silicon); Library Name: BBB_Derivat.SchLib
